# Altium schematic sheet FTDI.SchDoc(FTDI)
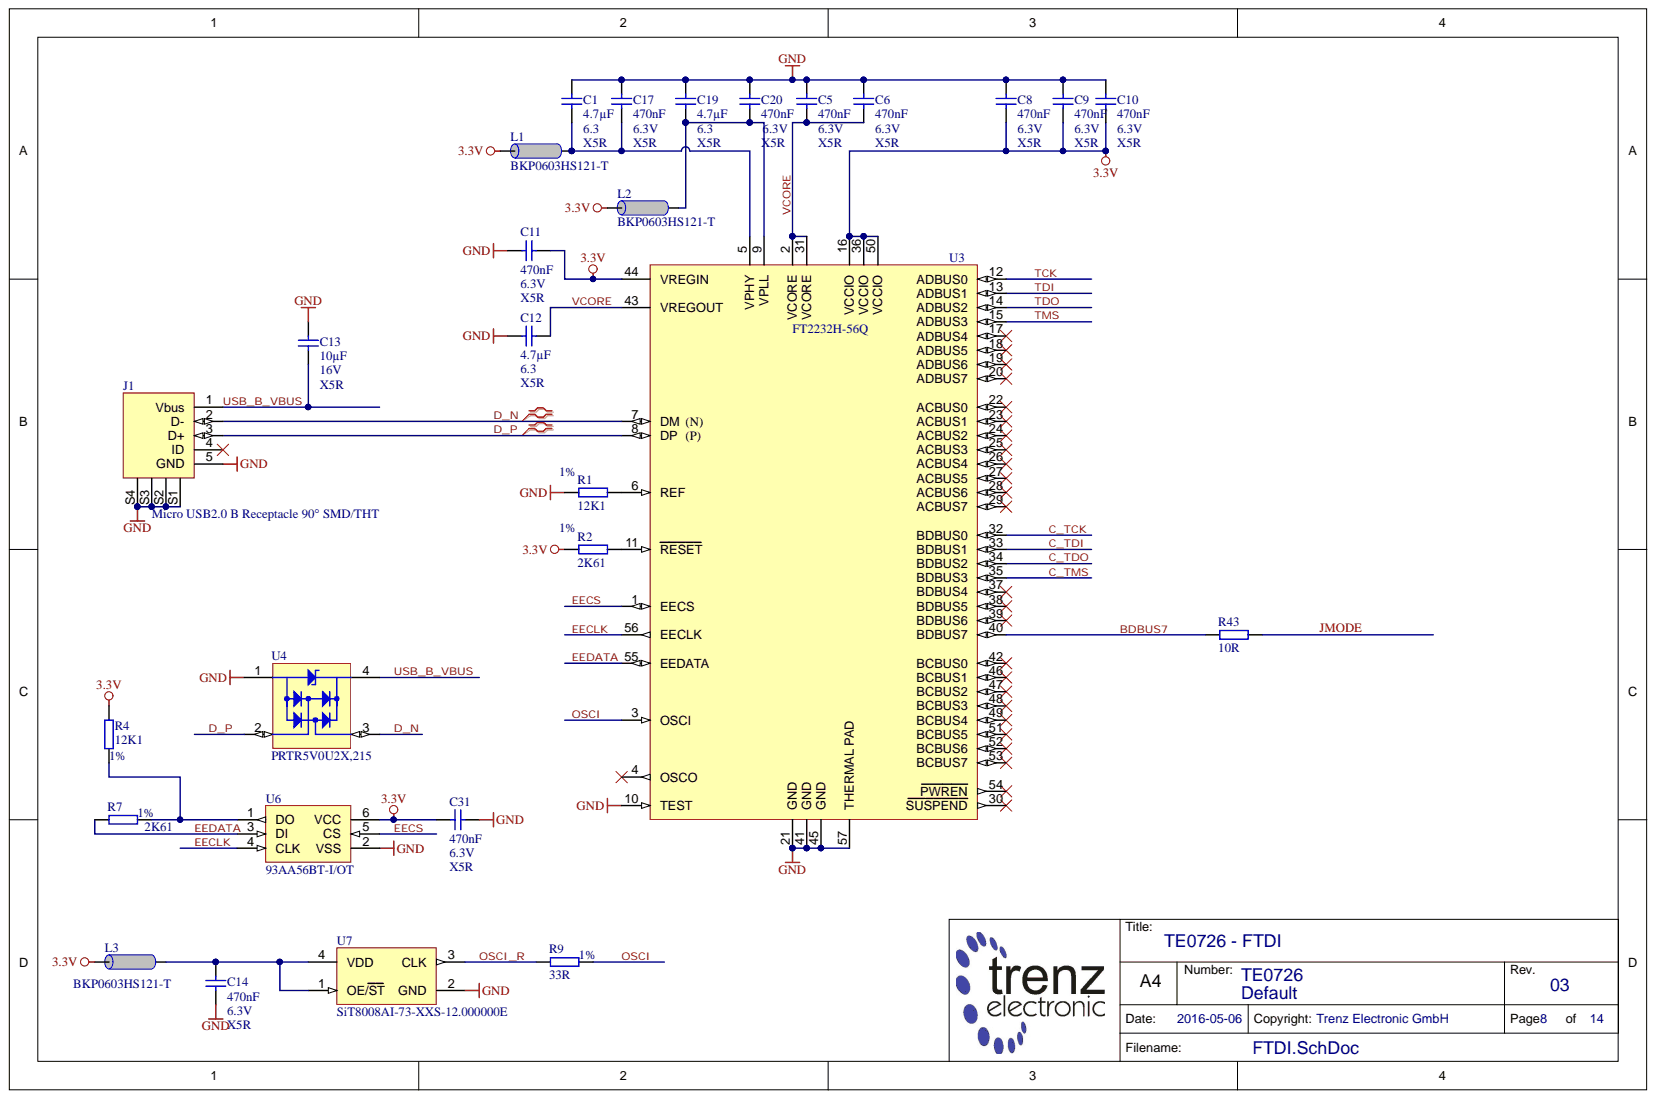
[diagram p0: FTDI.SchDoc]

## Parts
C1  4.7µF  Voltage: 6.3  [CAPC1005X60N]
C5  470nF  Technology: X5R  Voltage: 6.3V  [CAPC0603X33N]
C6  470nF  Technology: X5R  Voltage: 6.3V  [CAPC0603X33N]
C8  470nF  Technology: X5R  Voltage: 6.3V  [CAPC0603X33N]
C9  470nF  Technology: X5R  Voltage: 6.3V  [CAPC0603X33N]
C10  470nF  Technology: X5R  Voltage: 6.3V  [CAPC0603X33N]
C11  470nF  Voltage: 6.3V  [CAPC0603X33N]
C12  4.7µF  Technology: X5R  Voltage: 6.3  [CAPC1005X60N]
C13  10µF  Technology: X5R  Voltage: 16V  [CAPC1608X90N]
C14  470nF  Technology: X5R  Voltage: 6.3V  [CAPC0603X33N]
C17  470nF  Technology: X5R  Voltage: 6.3V  [CAPC0603X33N]
C19  4.7µF  Technology: X5R  Voltage: 6.3  [CAPC1005X60N]
C20  470nF  Technology: X5R  Voltage: 6.3V  [CAPC0603X33N]
C31  470nF  Voltage: 6.3V  [CAPC0603X33N]
J1  Micro USB2.0 B Receptacle 90° SMD/THT  [629105150521]
L1  BKP0603HS121-T  [INDC0603X33N]
L2  BKP0603HS121-T  [INDC0603X33N]
L3  BKP0603HS121-T  [INDC0603X33N]
R1  12K1  Tolerance: 1%  [RESC1005X40N]
R2  2K61  Tolerance: 1%  [RESC1005X40N]
R4  12K1  Tolerance: 1%  [RESC1005X40N]
R7  2K61  Tolerance: 1%  [RESC1005X40N]
R9  33R  Tolerance: 1%  [RESC0603X26N]
R43  10R  [RESC1005X40N]
U3  FT2232H-56Q  [QFN50P800X800-57T610X610N]
U4  PRTR5V0U2X,215  [SOT190P229X114-4N]
U6  93AA56BT-I/OT  [SOT95P280X145-6N]
U7  SiT8008AI-73-XXS-12.000000E  Database Table Name: CLK  [2016]

## Nets
3.3V: C8.1 C9.1 C10.1 C11.2 C31.1 L1.2 L2.2 L3.2 R2.1 R4.2 U3.16(VCCIO) U3.36(VCCIO) U3.44(VREGIN) U3.50(VCCIO) U6.6(VCC)
GND: C1.2 C5.2 C6.2 C8.2 C9.2 C10.2 C11.1 C12.1 C13.1 C14.1 C17.2 C19.2 C20.2 C31.2 J1.5(GND) J1.S1 J1.S2 J1.S3 J1.S4 R1.1 U3.10(TEST) U3.21(GND) U3.41(GND) U3.45(GND) U3.57(THERMAL_PAD) U4.1 U6.2(VSS) U7.2(GND)
BDBUS7: R43.1 U3.40(BDBUS7)
C_TCK: U3.32(BDBUS0)
C_TDI: U3.33(BDBUS1)
C_TDO: U3.34(BDBUS2)
C_TMS: U3.35(BDBUS3)
D_N: J1.2(D-) U3.7(DM) U4.3
D_P: J1.3(D+) U3.8(DP) U4.2
EECLK: U3.56(EECLK) U6.4(CLK)
EECS: U3.1(EECS) U6.5(CS)
EEDATA: R7.1 U3.55(EEDATA) U6.3(DI)
JMODE: R43.2
OSCI: R9.2 U3.3(OSCI)
OSCI_R: R9.1 U7.3(CLK)
TCK: U3.12(ADBUS0)
TDI: U3.13(ADBUS1)
TDO: U3.14(ADBUS2)
TMS: U3.15(ADBUS3)
USB_B_VBUS: C13.2 J1.1(Vbus) U4.4
VCORE: C5.1 C6.1 C12.2 U3.2(VCORE) U3.31(VCORE) U3.43(VREGOUT)
NetC1_1: C1.1 C17.1 L1.1 U3.5(VPHY)
NetC14_2: C14.2 L3.1 U7.1(OE/ST) U7.4(VDD)
NetC19_1: C19.1 C20.1 L2.1 U3.9(VPLL)
NetR1_2: R1.2 U3.6(REF)
NetR2_2: R2.2 U3.11(RESET)
NetR4_1: R4.1 R7.2 U6.1(DO)

Not connected: J1 4(ID); U3 4(OSCO) 17(ADBUS4) 18(ADBUS5) 19(ADBUS6) 20(ADBUS7) 22(ACBUS0) 23(ACBUS1) 24(ACBUS2) 25(ACBUS3) 26(ACBUS4) 27(ACBUS5) 28(ACBUS6) 29(ACBUS7) 30(SUSPEND) 37(BDBUS4) 38(BDBUS5) 39(BDBUS6) 42(BCBUS0) 46(BCBUS1) 47(BCBUS2) 48(BCBUS3) 49(BCBUS4) 51(BCBUS5) 52(BCBUS6) 53(BCBUS7) 54(PWREN)

## Library data of the parts
C1 C12 C19 = 24719: KON 4.7µF 6.3V X5R SMD 20%; Database Table Name: C_1005_0402; Mixed: SMT; Preferred: Yes; Value: 4.7u; AN: 24719; Case: 1005 (0402); Component Kind: Standard; Library Name: TE.DbLib; Tolerance: 20%
  C1: Technology: X5R
C5 C6 C8-C11 C14 C17 C20 C31 = 24921: KON 470nF X5R 6.3V SMD 20%; Database Table Name: C_0603_0201; Mixed: SMT; Preferred: Yes; Value: 470n; AN: 24921; Case: 0603 (0201); Component Kind: Standard; Library Name: TE.DbLib; Tolerance: 20%
  C11 C31: Technology: X5R
C13 = 25341: KON 10µF 16V X5R SMD 20%; Database Table Name: C_1608_0603; Mixed: SMT; Preferred: Yes; Value: 10u; AN: 25341; Case: 1608 (0603); Component Kind: Standard; Library Name: TE.DbLib; Tolerance: 20%
J1 = 27235: Database Table Name: CON_IO; Mixed: SMT; AN: 27235; Component Kind: Standard; Description: Micro USB2.0 B Receptacle 90° SMD/THT; H-ART: 629105150521; Library Name: TE.DbLib; HelpURL: B:\AD\adlib\datasheet\10118194-0001LF
L1-L3 = 25393: Ferritdurchführung SMD 0201; Database Table Name: L_0603_0201; Mixed: SMT; Preferred: Yes; Tolerance: 25%; AN: 25393; Case: 0603 (0201); Component Kind: Standard; H-ART: BKP0603HS121-T; Library Name: TE.DbLib; Trenz-Stock: Yes; HelpURL: B:\AD\adlib\datasheet\MULTILAYER CHIP BEAD INDUCTORS BK
R1 R4 = 22849: WID SMD 12K1 1% 0,063W; Database Table Name: R_1005_0402; Mixed: SMT; Preferred: Yes; Value: 12.1K; AN: 22849; Case: 1005 (0402); Component Kind: Standard; Library Name: TE.DbLib; Technology: TK100
R2 R7 = 23730: WID SMD 2K61 1% 0,063W; Database Table Name: R_1005_0402; Mixed: SMT; Preferred: Yes; Value: 2.61K; AN: 23730; Case: 1005 (0402); Component Kind: Standard; Library Name: TE.DbLib; Technology: TK100
R9 = 25021: WID SMD 33R 1% 0,05W; Database Table Name: R_0603_0201; Mixed: SMT; Preferred: Yes; Value: 33; AN: 25021; Case: 0603 (0201); Component Kind: Standard; Library Name: TE.DbLib; Technology: TK100; HelpURL: B:\AD\adlib\datasheet\R0201
R43 = 22844: WID SMD 10R 1% 0,063W; Database Table Name: R_1005_0402; Mixed: SMT; Preferred: Yes; Value: 10; AN: 22844; Case: 1005 (0402); Component Kind: Standard; Library Name: TE.DbLib; Technology: TK100; Tolerance: 1%
U3 = 27277: Database Table Name: IF; Mixed: SMT; Preferred: Yes; AN: 27277; Case: VQFN56; Component Kind: Standard; Description: FT2232H-56Q; H-ART: FT2232H-56Q; Library Name: TE.DbLib; Trenz-Stock: Yes; HelpURL: B:\AD\adlib\datasheet\FT2232H
U4 = 24840: Database Table Name: DIODE; Mixed: SMT; Preferred: Yes; AN: 24840; Case: SOT143; Component Kind: Standard; Description: PRTR5V0U2X,215; H-ART: PRTR5V0U2X,215; Library Name: TE.DbLib; HelpURL: B:\AD\adlib\datasheet\CD143A-SR05
U6 = 24730: Database Table Name: EEPROM; Mixed: SMT; Preferred: Yes; AN: 24730; Case: SOT23-6; Component Kind: Standard; Description: 93AA56BT-I/OT; H-ART: 93AA56BT-I/OT; Library Name: TE.DbLib; Trenz-Stock: Yes; HelpURL: B:\AD\adlib\datasheet\93AA56A
U7 = 25325: SiT8008AI-73-XXS-12.000000E MEMS Oszillator 12MHz; Mixed: SMT; Preferred: Yes; Value: 12M; AN: 25325; Case: 2.0  x 1.6mm; Component Kind: Standard; H-ART: SiT8008AI-73-XXS-12.000000E; Library Name: TE.DbLib; Trenz-Stock: Yes; HelpURL: B:\AD\adlib\datasheet\SiT8008
